# Altium schematic sheet TF_CARD.SchDoc(TF_CARD)
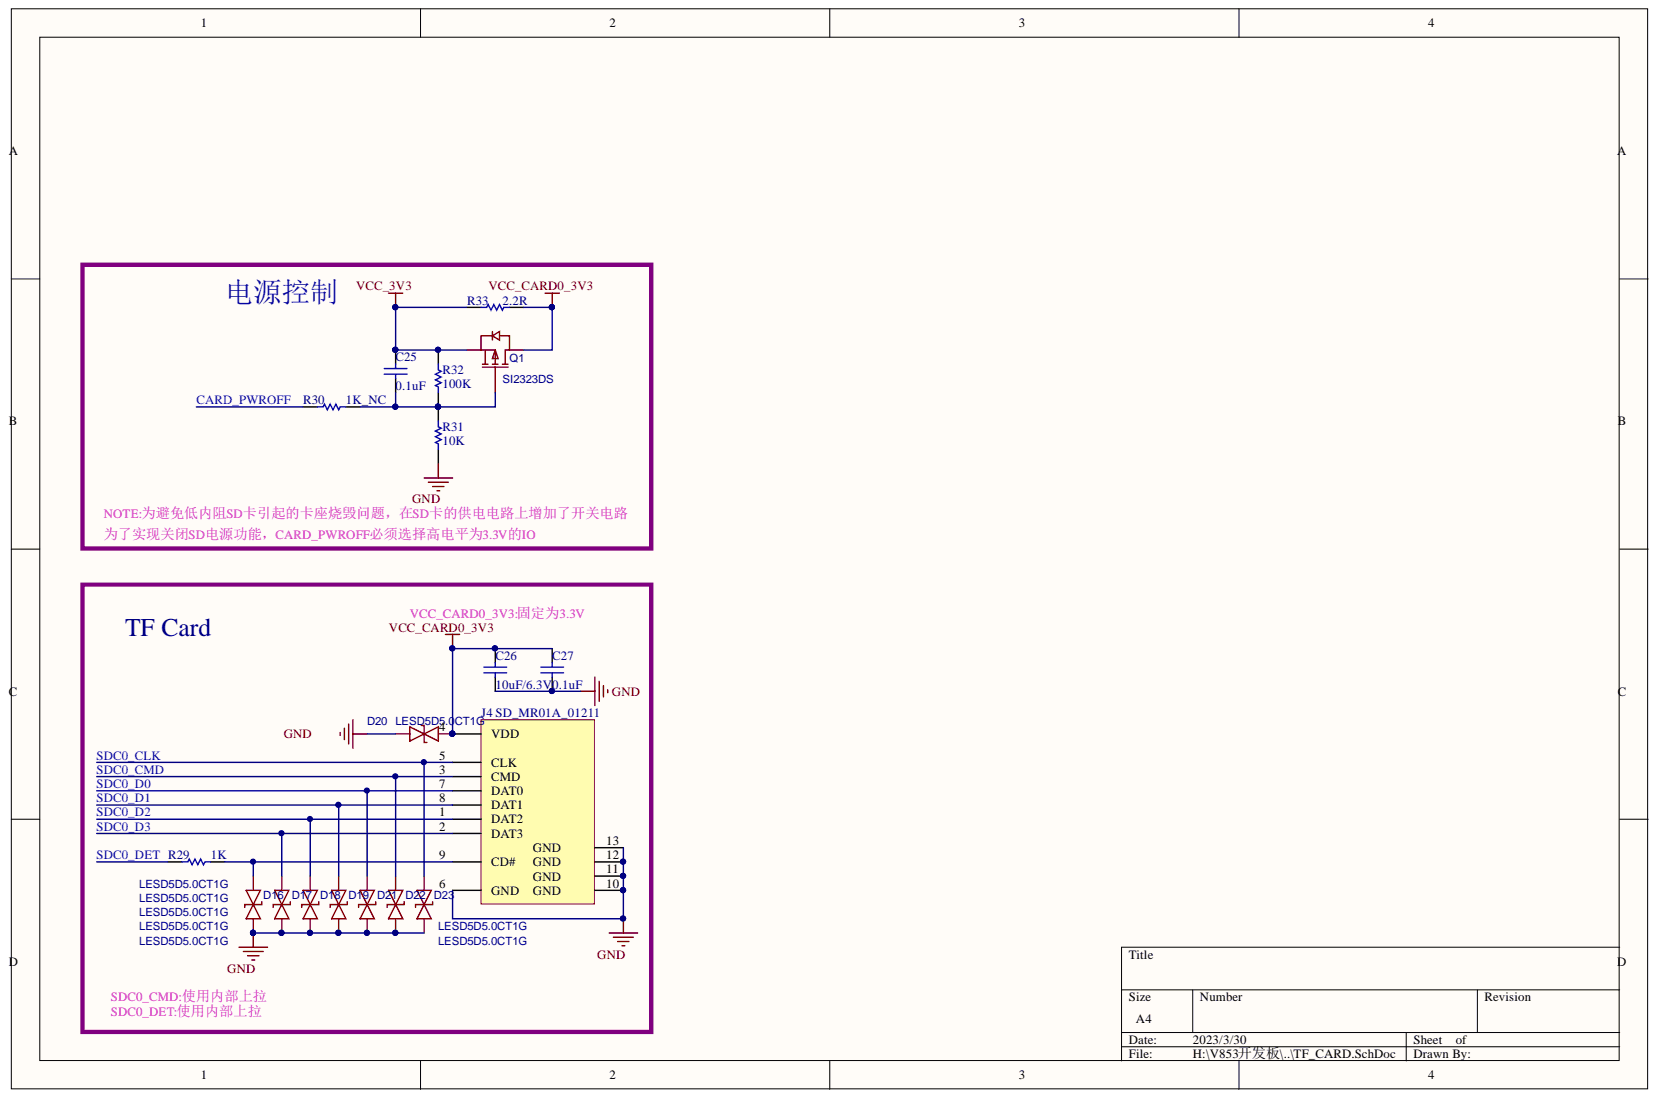
[diagram p0: TF_CARD.SchDoc]

## Parts
C25  0.1uF  [0402]
C26  10uF/6.3V  [0402]
C27  0.1uF  [0402]
D16  LESD5D5.0CT1G  [SOD-523_L1.2-W0.8-LS1.6-BI]
D17  LESD5D5.0CT1G  [SOD-523_L1.2-W0.8-LS1.6-BI]
D18  LESD5D5.0CT1G  [SOD-523_L1.2-W0.8-LS1.6-BI]
D19  LESD5D5.0CT1G  [SOD-523_L1.2-W0.8-LS1.6-BI]
D20  LESD5D5.0CT1G  [SOD-523_L1.2-W0.8-LS1.6-BI]
D21  LESD5D5.0CT1G  [SOD-523_L1.2-W0.8-LS1.6-BI]
D22  LESD5D5.0CT1G  [SOD-523_L1.2-W0.8-LS1.6-BI]
D23  LESD5D5.0CT1G  [SOD-523_L1.2-W0.8-LS1.6-BI]
J4  SD_MR01A_01211  [SD-MR01A-01211]
Q1  SI2323DS  [SOT23]
R29  1K  [0402]
R30  1K_NC  [0402]
R31  10K  [0402]
R32  100K  [0402]
R33  2.2R  [0603]

## Nets
GND: C26.2 C27.2 D16.1 D17.1 D18.1 D19.1 D20.2 D21.1 D22.1 D23.1 J4.6(GND) J4.10 J4.11 J4.12 J4.13 R31.2
VCC_3V3: C25.1 Q1.2 R32.1 R33.1
VCC_CARD0_3V3: C26.1 C27.1 D20.1 J4.4(VDD) Q1.3 R33.2
CARD_PWROFF: R30.2
SDC0_CLK: D23.2 J4.5(CLK)
SDC0_CMD: D22.2 J4.3(CMD)
SDC0_D0: D21.2 J4.7(DAT0)
SDC0_D1: D19.2 J4.8(DAT1)
SDC0_D2: D18.2 J4.1(DAT2)
SDC0_D3: D17.2 J4.2(DAT3)
SDC0_DET: R29.2
NetC25_2: C25.2 Q1.1 R30.1 R31.1 R32.2
NetD16_2: D16.2 J4.9(CD#) R29.1

## Library data of the parts
C25-C27 = Cap: Capacitor; PartNumber: CAP100NF; Component Kind: Standard; LatestRevisionDate: 17-Jul-2002; LatestRevisionNote: Re-released for DXP Platform.; Library Name: Miscellaneous Devices.IntLib; PackageReference: 0402; Published: 8-Jun-2000; Signal Integrity: Cap; Simulation: CAP; Publisher: Altium Limited
  C25 C27: Value: 0.1uF
  C26: Value: 10uF/6.3V
D16-D23 = LESD5D5.0CT1G: BOM_Manufacturer: Leshan Radio; BOM_Paste Type: expand; Contributor: LCSC; BOM_Manufacturer Part: LESD5D5.0CT1G; BOM_Supplier: LCSC; BOM_Supplier Part: C383211; Component Kind: Standard; Library Name: HM2.SchLib; spicePre: D; spiceSymbolName: LESD5D5.0CT1G
Q1 = AO3401A: BOM_Manufacturer: AOS; SMT Type: Basic; BOM_LCSC Assembly: Yes; BOM_Manufacturer Part: AO3401A; BOM_Supplier: LCSC; BOM_Supplier Part: C15127; Component Kind: Standard; image: //image.lceda.cn/szlcsc/C15127.jpg; spicePre: Q; spiceSymbolName: AO3401A
R29-R33 = Res1: Resistor; PartNumber: RES2.2K; Component Kind: Standard; LatestRevisionDate: 17-Jul-2002; LatestRevisionNote: Re-released for DXP Platform.; Library Name: Miscellaneous Devices.IntLib; PackageReference: 0402; Published: 8-Jun-2000; Signal Integrity: Res; Simulation: RESISTOR; Publisher: Altium Limited
  R29: Value: 1K
  R30: Value: 1K_NC
  R31: Value: 10K
  R32: Value: 100K
  R33: Value: 2.2R
J4 = SD_MR01A_01211: Component Kind: Standard; Library Name: HM2.SchLib
